# Altium schematic sheet Bottom.SchDoc
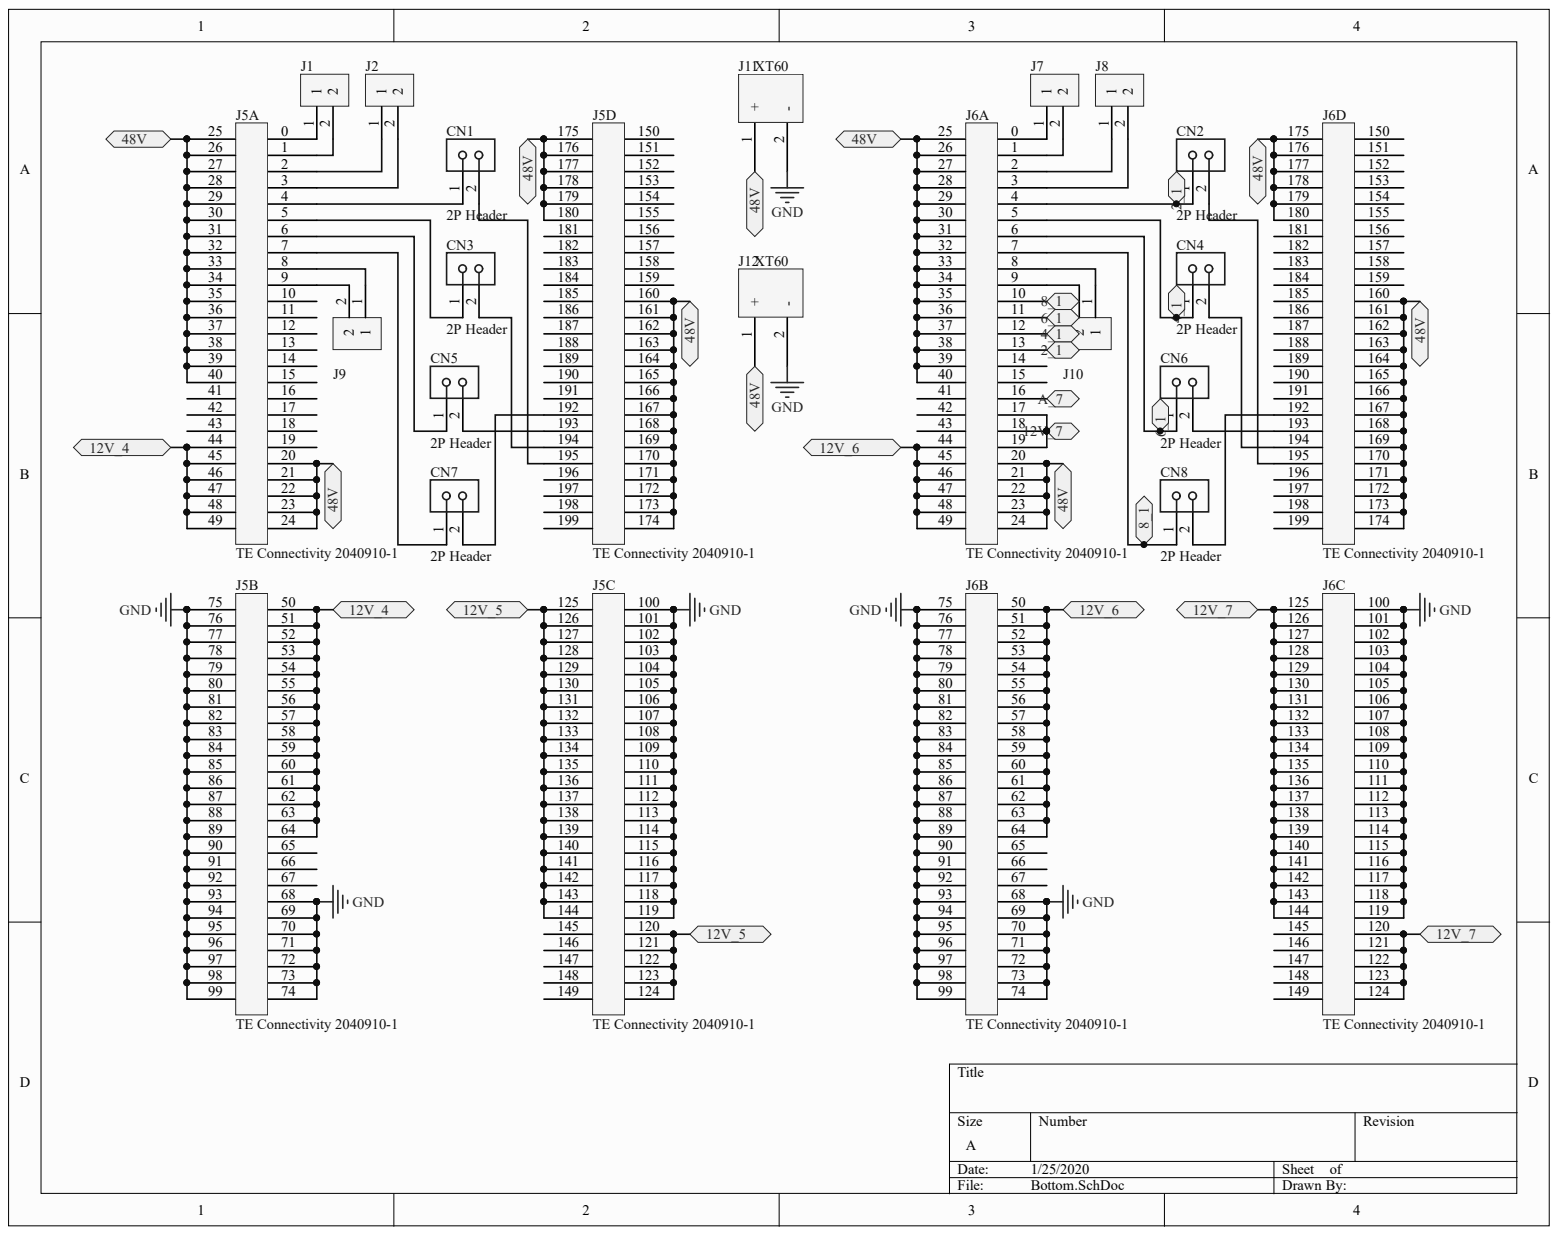
[diagram p0: Bottom.SchDoc]

## Parts
CN1  2P Header  [SIP2]
CN2  2P Header  [SIP2]
CN3  2P Header  [SIP2]
CN4  2P Header  [SIP2]
CN5  2P Header  [SIP2]
CN6  2P Header  [SIP2]
CN7  2P Header  [SIP2]
CN8  2P Header  [SIP2]
J1  Würth Elektronik 61300211121  [PCB-omgsbkhp90n7lpri88og-1]  (value not drawn)
J2  Würth Elektronik 61300211121  [PCB-omgsbkhp90n7lpri88og-1]  (value not drawn)
J5  TE Connectivity 2040910-1  Manufacturer Part Number: 2040910-1  [PCB-94s10idd7zcjgt3pt9oj-1]  (parts A,B,C,D)
J6  TE Connectivity 2040910-1  Manufacturer Part Number: 2040910-1  [PCB-94s10idd7zcjgt3pt9oj-1]  (parts A,B,C,D)
J7  Würth Elektronik 61300211121  [PCB-omgsbkhp90n7lpri88og-1]  (value not drawn)
J8  Würth Elektronik 61300211121  [PCB-omgsbkhp90n7lpri88og-1]  (value not drawn)
J9  Würth Elektronik 61300211121  [PCB-omgsbkhp90n7lpri88og-1]  (value not drawn)
J10  Würth Elektronik 61300211121  [PCB-omgsbkhp90n7lpri88og-1]  (value not drawn)
J11  XT60  [PCB-0uujl74xmkys5mfjn62d-1]
J12  XT60  [PCB-0uujl74xmkys5mfjn62d-1]

## Ports
2_1, 4_1, 6_1, 8_1, 12V_4, 12V_5, 12V_6, 12V_7, 48V, A_7

## Nets
GND: J5.68 J5.69 J5.70 J5.71 J5.72 J5.73 J5.74 J5.75 J5.76 J5.77 J5.78 J5.79 J5.80 J5.81 J5.82 J5.83 J5.84 J5.85 J5.86 J5.87 J5.88 J5.89 J5.90 J5.91 J5.92 J5.93 J5.94 J5.95 J5.96 J5.97 J5.98 J5.99 J5.100 J5.101 J5.102 J5.103 J5.104 J5.105 J5.106 J5.107 J5.108 J5.109 J5.110 J5.111 J5.112 J5.113 J5.114 J5.115 J5.116 J5.117 J5.118 J5.119 J6.68 J6.69 J6.70 J6.71 J6.72 J6.73 J6.74 J6.75 J6.76 J6.77 J6.78 J6.79 J6.80 J6.81 J6.82 J6.83 J6.84 J6.85 J6.86 J6.87 J6.88 J6.89 J6.90 J6.91 J6.92 J6.93 J6.94 J6.95 J6.96 J6.97 J6.98 J6.99 J6.100 J6.101 J6.102 J6.103 J6.104 J6.105 J6.106 J6.107 J6.108 J6.109 J6.110 J6.111 J6.112 J6.113 J6.114 J6.115 J6.116 J6.117 J6.118 J6.119 J11.2 J12.2
2_1: CN2.1 J6.4 J6.13 ; port 2_1
4_1: CN4.1 J6.5 J6.12 ; port 4_1
6_1: CN6.1 J6.6 J6.11 ; port 6_1
8_1: CN8.1 J6.7 J6.10 ; port 8_1
12V_4: J5.44 J5.45 J5.46 J5.47 J5.48 J5.49 J5.50 J5.51 J5.52 J5.53 J5.54 J5.55 J5.56 J5.57 J5.58 J5.59 J5.60 J5.61 J5.62 J5.63 J5.64 ; port 12V_4
12V_5: J5.120 J5.121 J5.122 J5.123 J5.124 J5.125 J5.126 J5.127 J5.128 J5.129 J5.130 J5.131 J5.132 J5.133 J5.134 J5.135 J5.136 J5.137 J5.138 J5.139 J5.140 J5.141 J5.142 J5.143 J5.144 ; port 12V_5
12V_6: J6.44 J6.45 J6.46 J6.47 J6.48 J6.49 J6.50 J6.51 J6.52 J6.53 J6.54 J6.55 J6.56 J6.57 J6.58 J6.59 J6.60 J6.61 J6.62 J6.63 J6.64 ; port 12V_6
12V_7: J6.17 J6.18 J6.19 J6.120 J6.121 J6.122 J6.123 J6.124 J6.125 J6.126 J6.127 J6.128 J6.129 J6.130 J6.131 J6.132 J6.133 J6.134 J6.135 J6.136 J6.137 J6.138 J6.139 J6.140 J6.141 J6.142 J6.143 J6.144 ; port 12V_7
48V: J5.20 J5.21 J5.22 J5.23 J5.24 J5.25 J5.26 J5.27 J5.28 J5.29 J5.30 J5.31 J5.32 J5.33 J5.34 J5.35 J5.36 J5.37 J5.38 J5.39 J5.40 J5.160 J5.161 J5.162 J5.163 J5.164 J5.165 J5.166 J5.167 J5.168 J5.169 J5.170 J5.171 J5.172 J5.173 J5.174 J5.175 J5.176 J5.177 J5.178 J5.179 J5.180 J6.20 J6.21 J6.22 J6.23 J6.24 J6.25 J6.26 J6.27 J6.28 J6.29 J6.30 J6.31 J6.32 J6.33 J6.34 J6.35 J6.36 J6.37 J6.38 J6.39 J6.40 J6.160 J6.161 J6.162 J6.163 J6.164 J6.165 J6.166 J6.167 J6.168 J6.169 J6.170 J6.171 J6.172 J6.173 J6.174 J6.175 J6.176 J6.177 J6.178 J6.179 J6.180 J11.1 J12.1 ; port 48V
A_7: J6.16 ; port A_7
NetCN1_1: CN1.1 J5.4
NetCN1_2: CN1.2 J5.195
NetCN2_2: CN2.2 J6.195
NetCN3_1: CN3.1 J5.5
NetCN3_2: CN3.2 J5.194
NetCN4_2: CN4.2 J6.194
NetCN5_1: CN5.1 J5.6
NetCN5_2: CN5.2 J5.193
NetCN6_2: CN6.2 J6.193
NetCN7_1: CN7.1 J5.7
NetCN7_2: CN7.2 J5.192
NetCN8_2: CN8.2 J6.192
NetJ1_1: J1.1 J5.0
NetJ1_2: J1.2 J5.1
NetJ2_1: J2.1 J5.2
NetJ2_2: J2.2 J5.3
NetJ5_8: J5.8 J9.1
NetJ5_9: J5.9 J9.2
NetJ6_0: J6.0 J7.1
NetJ6_1: J6.1 J7.2
NetJ6_2: J6.2 J8.1
NetJ6_3: J6.3 J8.2
NetJ6_8: J6.8 J10.1
NetJ6_9: J6.9 J10.2

Not connected: J5 10 11 12 13 14 15 16 17 18 19 41 42 43 65 66 67 145 146 147 148 149 150 151 152 153 154 155 156 157 158 159 181 182 183 184 185 186 187 188 189 190 191 196 197 198 199; J6 14 15 41 42 43 65 66 67 145 146 147 148 149 150 151 152 153 154 155 156 157 158 159 181 182 183 184 185 186 187 188 189 190 191 196 197 198 199

## Library data of the parts
CN1-CN8 = CMP-fc43341a67d62384-2: Conn Header .100" Sngl Str 2pos; Manufacturer Part Number: PRPC002SAAN-RC; Color: Black; Component Kind: Standard; Library Name: CommunityVault; Mounting Style: Through Hole; OctopartID: fc43341a67d62384; Octopart URL: http://octopart.com/prpc002saan-rc-sullins-22265587; Packaging: Bulk
J1 J2 J7-J10 = CMP-748fbcb73d6bab48-27: THT Vertical Pin Header WR-PHD, Pitch 2.54 mm, Single Row, 2 pins; Contact Resistance: 20.0 mΩ; Current Rating: 3.00 A; Dielectric Withstanding Voltage: 500 V; Gender: Male; Lead-Free Status: Lead Free; Number of Contacts: 2; Operating Voltage: 250 V; Component Kind: Standard; Contact Material: Copper; Contact Plating: Gold; Library Name: CommunityVault; Manufacturer Part Number: 61300211121; Mounting Style: Through Hole; Number of Pins: 2; OctopartID: 748fbcb73d6bab48; Operating Temperature: -40.0 °C (min); Orientation: Vertical; Packaging: Bag; REACH SVHC Compliance: No SVHC; RoHS: Compliant
J5 J6 = CMP-33f9f842d950ec3e-13: Conn SO DIMM Socket SKT 200 POS 0.6mm Solder ST SMD Tray/Box; ELV Compliant: Compliant; Component Kind: Standard; Contact Material: Copper Alloy; Contact Plating: Tin, Gold; Flammability Rating: UL 94 V-0; Housing Material: Thermoplastic; Library Name: CommunityVault; Lifecycle Status: Active; Mounting Style: Surface Mount, PCB; OctopartID: 33f9f842d950ec3e; Octopart URL: https://octopart.com/2040910-1-te+connectivity-45207503; Operating Temperature: -55.0 °C to 85.0 °C; Packaging: Tray, Box; RoHS: Compliant
J11 J12 = CMP-db0d1124dea204ca-10: batteries; Manufacturer Part Number: XT60 YELLOW; Color: Yellow; Component Kind: Standard; Library Name: CommunityVault; Octopart URL: https://octopart.com/xt60+yellow-pololu-52633801
